# Altium schematic sheet ADC_U.SchDoc
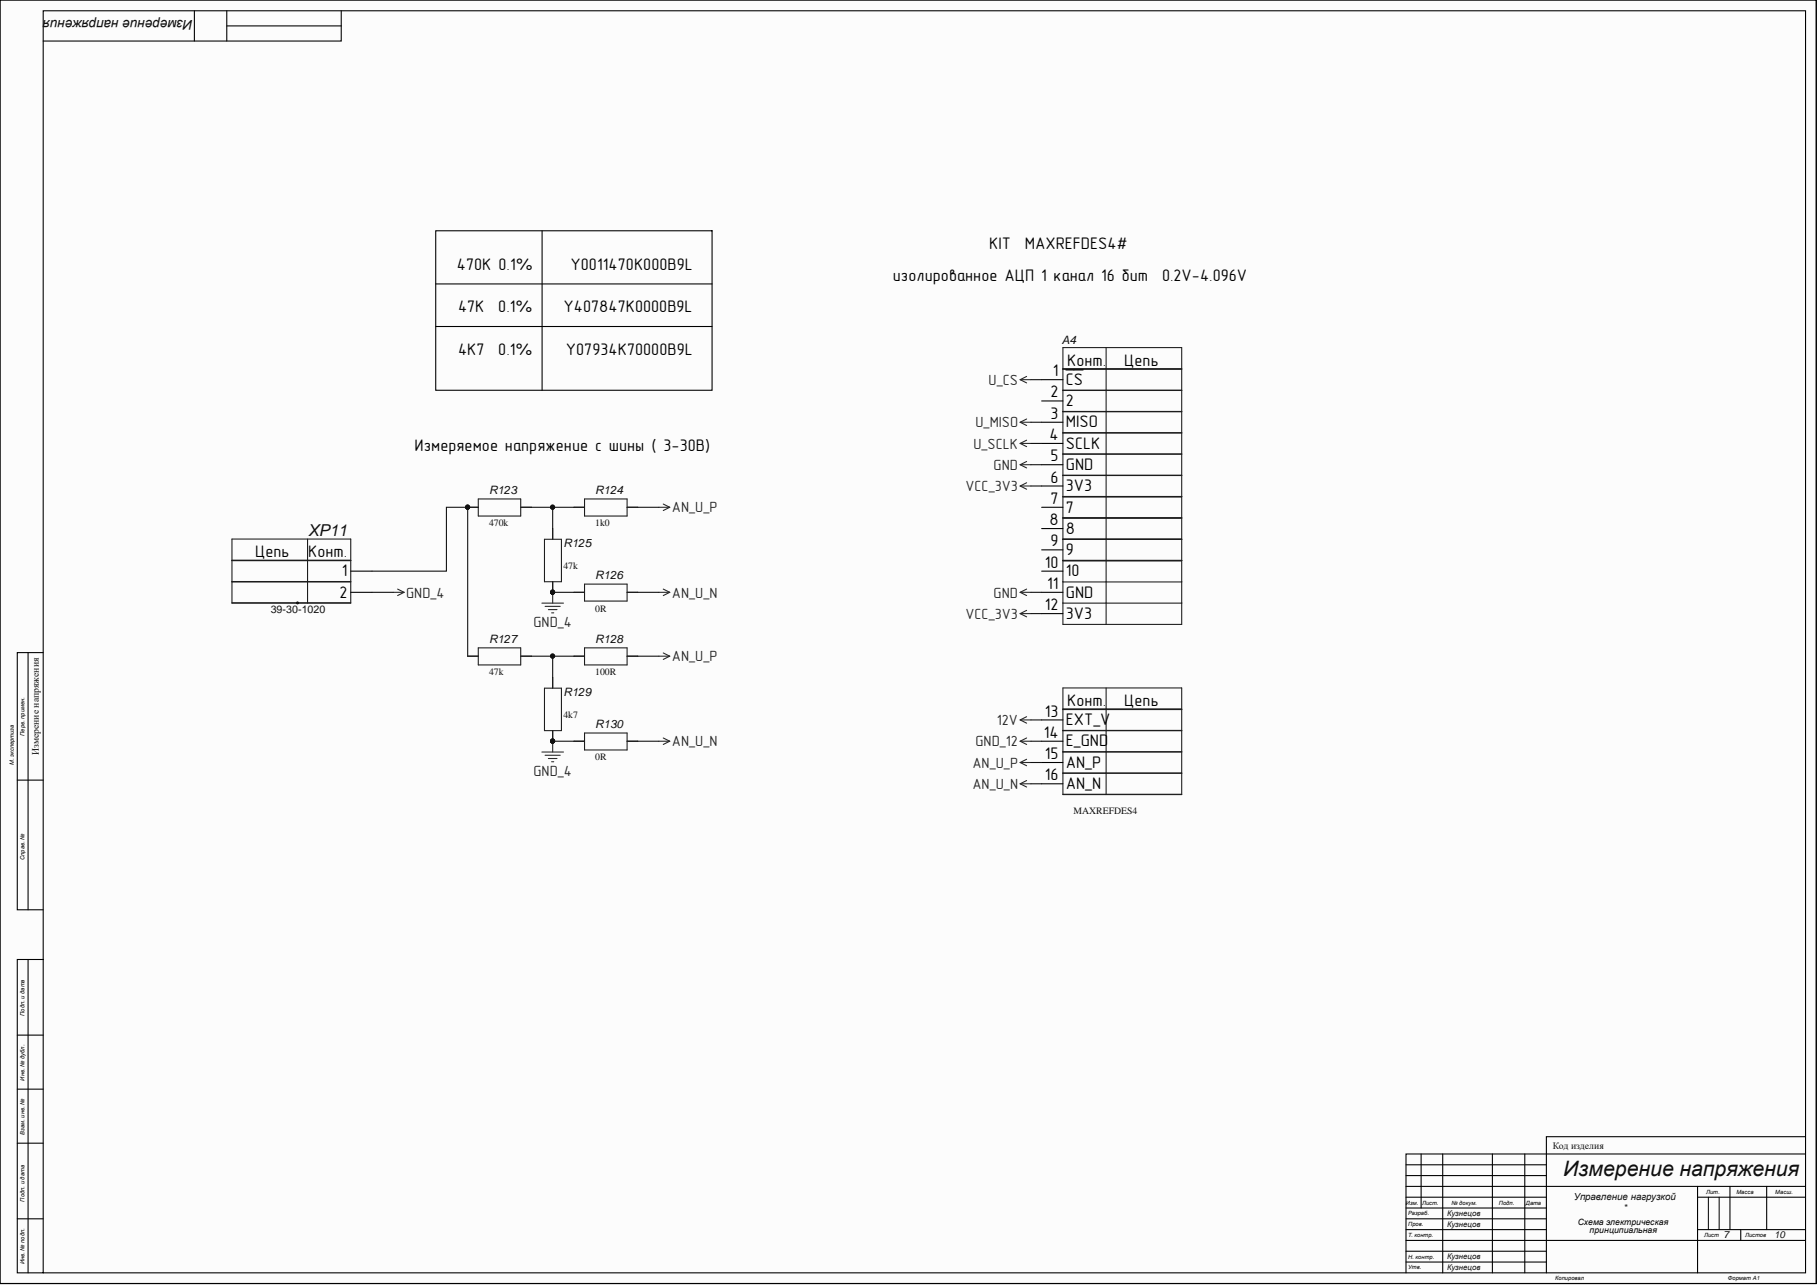
[diagram p0: ADC_U.SchDoc]

## Parts
A4  MAXREFDES4  [MAXREFDES4]
R123  value 470k  [KNP-1W]  (hidden comment: C2-29B)
R124  1k0  [R2010]
R125  value 47k  [KNP-1W]  (hidden comment: C2-29B)
R126  0R  [R2010]
R127  value 47k  [KNP-1W]  (hidden comment: C2-29B)
R128  100R  [R2010]
R129  value 4k7  [KNP-1W]  (hidden comment: C2-29B)
R130  0R  [R2010]
XP11  39-30-1020  [MINI-FIT JR -2]

## Nets
12V: A4.13(EXT_V)
AN_U_N: A4.16(AN_N) R126.2 R130.2
AN_U_P: A4.15(AN_P) R124.2 R128.2
GND: A4.5(GND) A4.11(GND)
GND_4: R125.1 R126.1 R129.1 R130.1 XP11.2
GND_12: A4.14(E_GND)
U_CS: A4.1(CS)
U_MISO: A4.3(MISO)
U_SCLK: A4.4(SCLK)
VCC_3V3: A4.6(3V3) A4.12(3V3)
NetR123_1: R123.1 R127.1 XP11.1
NetR123_2: R123.2 R124.1 R125.2
NetR127_2: R127.2 R128.1 R129.2

Not connected: A4 2 7 8 9 10

## Library data of the parts
A4 = MAXREFDES4: STM32F769 Discovery Kit STM32F7 MCU 32-Bit ARM®; Manufacturer: Maxim; Component Kind: Standard; ComponentLink1Description: Параметры; ComponentLink2Description: Сайт производителя; ManufacturerPartNumber: MAXREFDES4; Author: Eleman; Library Name: Eleman_Plc.SchLib
R123 R125 R127 R129 = C2-29B: Резистор тонкоплёночный; Manufacturer: Эркон; Power\Voltage: 0.5W; TKE: 5ррм; Tolerance: 0.1%; Component Kind: Standard; ComponentLink1Description: Параметры; ComponentLink2Description: Сайт производителя; ManufacturerPartNumber: C2-29B; Author: Eleman; ComponentLink1URL: d:\YandexDisk\Project_Altium\Lib_Eleman\; Library Name: Eleman_Resistor.SchLib
R124 R126 R128 R130 = R2010: Импортный постоянный углеродистый резистор; Manufacturer: Vishay Dale; Power\Voltage: 0.75W; Tolerance: 5%; Component Kind: Standard; ComponentLink1Description: Параметры; ComponentLink2Description: Сайт производителя; ManufacturerPartNumber: R2010; Корпус: SMD 2010; Author: Eleman; Library Name: Eleman_Resistor.SchLib
  R124: Value: 1k0
  R126 R130: Value: 0R
  R128: Value: 100R
XP11 = 39-30-1020: Разъем; Manufacturer: Molex; Rem: Mini-Fit Jr 2; Корпус: Mini Fit; Component Kind: Standard; ComponentLink1Description: Параметры; ComponentLink2Description: Сайт производителя; ManufacturerPartNumber: 39-30-1020; Author: Eleman; ComponentLink1URL: C:\Demo\Datasheet; Library Name: Eleman_Connector.SchLib
